# Altium schematic sheet AIM2_v0.9_PWR.SchDoc
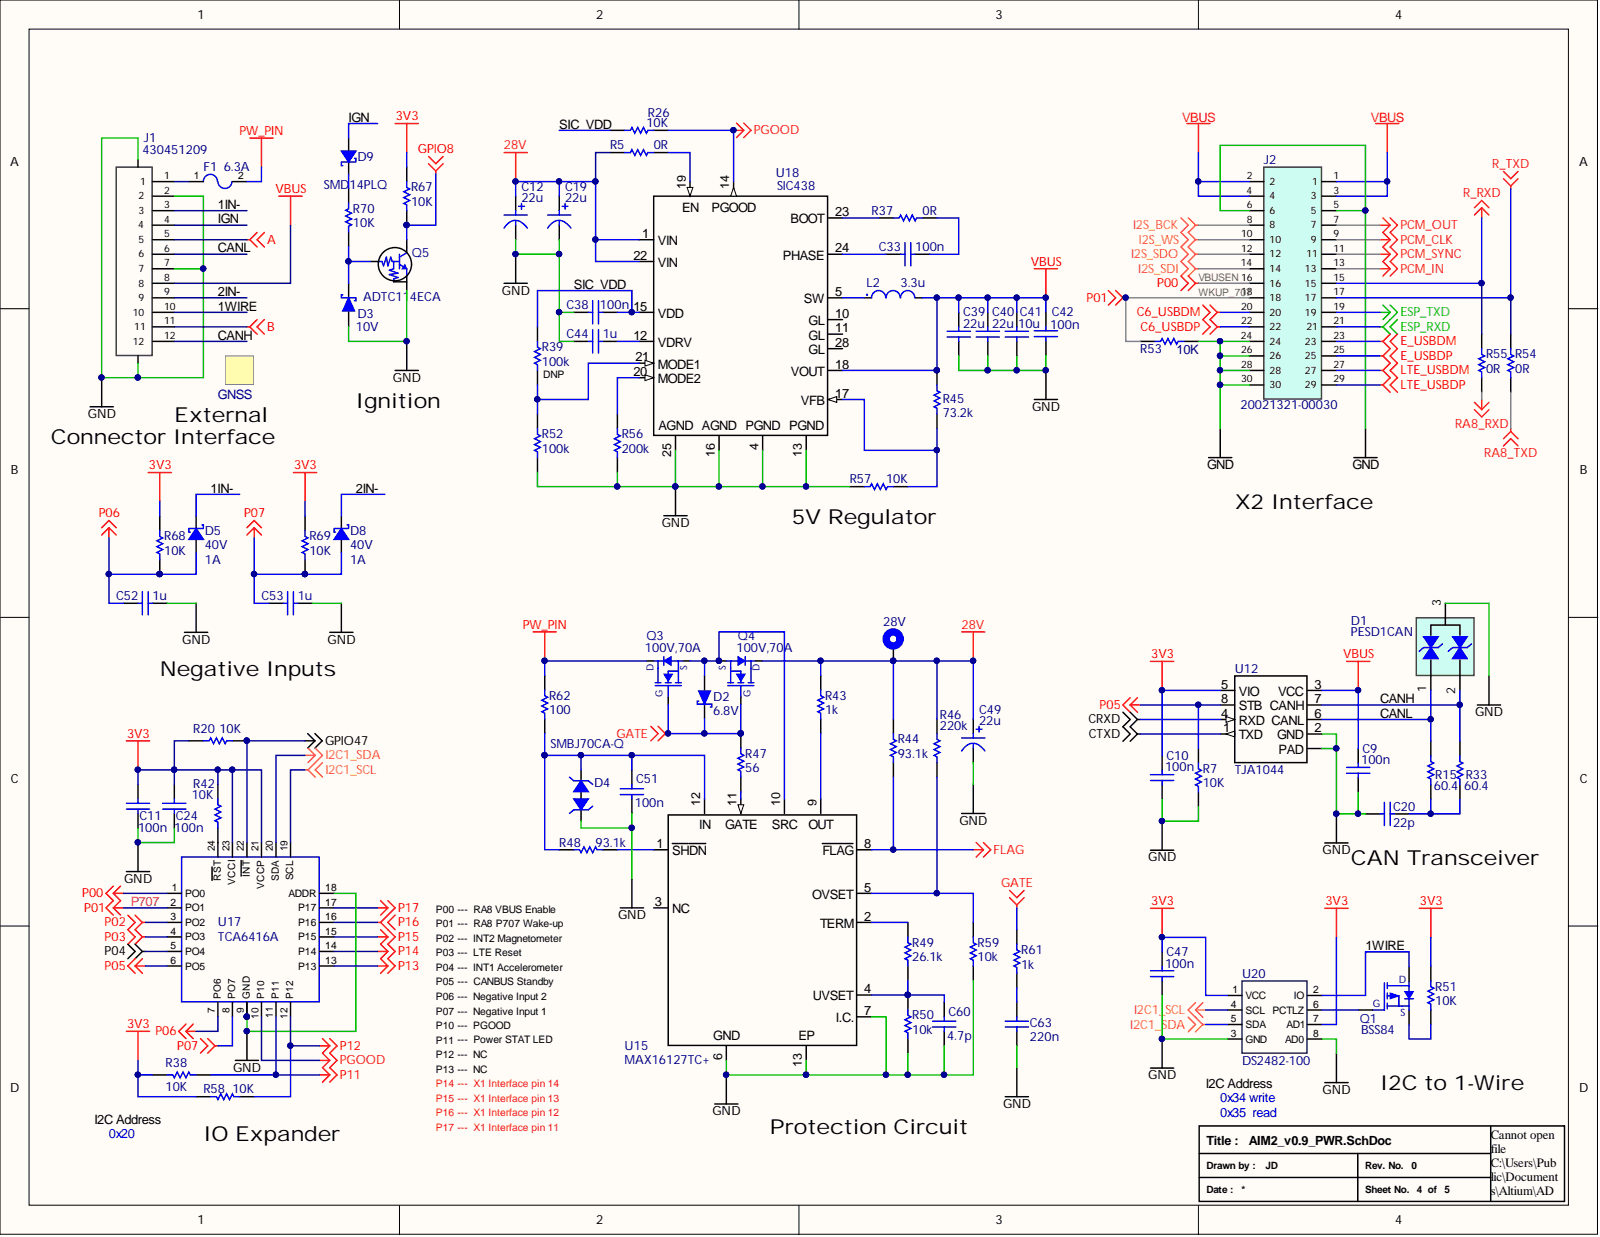
[diagram p0: AIM2_v0.9_PWR.SchDoc]

## Parts
28V  Testpoint_1_5  [Testpoint_1_5]  (value not drawn)
C9  100n  [CAP_0402_ghost]
C10  100n  [CAP_0402_ghost]
C11  100n  [CAP_0402]
C12  22u  [Alum_4x5.8]
C19  22u  [Alum_4x5.8]
C20  22p  [CAP_0402_ghost]
C24  100n  [CAP_0402]
C33  100n  [CAP_0402]
C38  100n  [CAP_0402]
C39  22u  [CAP_0603]
C40  22u  [CAP_0603]
C41  10u  [CAP_0603]
C42  100n  [CAP_0402]
C44  1u  [CAP_0402]
C47  100n  [CAP_0402]
C49  22u  [Alum_4x5.8]
C51  100n  [CAP_0603]
C52  1u  [CAP_0402]
C53  1u  [CAP_0402]
C60  4.7p  [CAP_0402]
C63  220n  [CAP_0603]
D1  PESD1CAN  [SOT 23_ghost]
D2  6.8V  [SOD323a]
D3  10V  [SOD323a]
D4  SMBJ70CA-Q  [DO-214AA]
D5  40V  Current: 1A  [SOD123]
D8  40V  Current: 1A  [SOD123]
D9  SMD14PLQ  [SOD123F-2]
F1  6.3A  [Fuse_3216]
GNSS  Antenna_18x18  [20x20]  (value not drawn)
J1  430451209  [Molex_Microfit_43045_1209]
J2  20021321-00030  [Minitek_20021321-00030T4LF]
L2  3.3u  [Ind_10.16x10.16]
Q1  BSS84  [SOT23-3]
Q3  100V,70A  [DPAK-3 (TO-252-3)]
Q4  100V,70A  [DPAK-3 (TO-252-3)]
Q5  ADTC114ECA  [SOT23-3]
R5  0R  [RES_0402]
R7  10K  [RES_0402_ghost]
R15  60.4  [RES_0402_ghost]
R20  10K  [RES_0402]
R26  10K  [RES_0402]
R33  60.4  [RES_0402_ghost]
R37  0R  [RES_0402]
R38  10K  [RES_0402]
R39  100k  [RES_0402_ghost]
R42  10K  [RES_0402]
R43  1k  [RES_0603]
R44  93.1k  [RES_0603]
R45  73.2k  [RES_0402]
R46  220k  [RES_0603]
R47  56  [RES_0603]
R48  93.1k  [RES_0603]
R49  26.1k  [RES_0603]
R50  10k  [RES_0603]
R51  10K  [RES_0402]
R52  100k  [RES_0402]
R53  10K  [RES_0402_ghost]
R54  0R  [RES_0402]
R55  0R  [RES_0402]
R56  200k  [RES_0402]
R57  10K  [RES_0402]
R58  10K  [RES_0402]
R59  10k  [RES_0603]
R61  1k  [RES_0603]
R62  100  [RES_1206]
R67  10K  [RES_0402]
R68  10K  [RES_0402]
R69  10K  [RES_0402]
R70  10K  [RES_0402]
U12  TJA1044  [S_PVSON_N8_DRB-A_ghost]
U15  MAX16127TC+  [TQFN-12L 3x3]
U17  TCA6416A  [S_PWQFN_N24_RTW]
U18  SIC438  [PowerPak_MLP44-24L]
U20  DS2482-100  [SOIC 150 (8-pin)]

## Nets
3V3: C10.2 C11.2 C24.2 C47.2 R20.1 R38.1 R42.1 R51.2 R58.1 R67.2 R68.2 R69.2 U12.5(VIO) U17.21(VCCP) U17.23(VCCI) U20.1(VCC) U20.7(AD1)
28V: 28V.1 C12.1 C19.1 C49.1 Q4.2 R5.1 R43.2 R44.2 R46.2 U18.1(VIN) U18.22(VIN)
A: J1.5
B: J1.11
C6_USBDM: J2.20
C6_USBDP: J2.22
CRXD: U12.4(RXD)
CTXD: U12.1(TXD)
E_USBDM: J2.23
E_USBDP: J2.25
ESP_RXD: J2.21
ESP_TXD: J2.19
FLAG: R44.1 U15.8(FLAG)
GATE: D2.K Q3.1 Q4.1 R47.2 R61.2
GND: C9.1 C10.1 C11.1 C12.2 C19.2 C20.1 C24.1 C38.1 C39.1 C40.1 C41.1 C42.1 C44.2 C47.1 C49.2 C51.1 C52.2 C53.2 C60.1 C63.1 D1.3 D3.A D4.A J1.0 J1.2 J1.7 J2.5 J2.6 J2.24 J2.26 J2.28 J2.30 Q5.2 R7.1 R50.1 R52.1 R53.1 R56.1 R57.2 R59.1 U12.0(PAD) U12.2(GND) U15.6 U15.7(I.C.) U15.13(EP) U17.9(GND) U17.18(ADDR) U17.25 U18.4 U18.13 U18.16 U18.25 U20.3(GND) U20.8(AD0)
GPIO8: Q5.3 R67.1
GPIO47: R20.2 U17.22(INT)
I2C1_SCL: U17.19(SCL) U20.4(SCL)
I2C1_SDA: U17.20(SDA) U20.5(SDA)
I2S_BCK: J2.8
I2S_SDI: J2.14
I2S_SDO: J2.12
I2S_WS: J2.10
LTE_USBDM: J2.27
LTE_USBDP: J2.29
P00: J2.16 U17.1(PO0)
P02: U17.3(PO2)
P03: U17.4(PO3)
P04: U17.5(PO4)
P05: R7.2 U12.8(STB) U17.6(PO5)
P06: C52.1 D5.A R68.1 U17.7(PO6)
P07: C53.1 D8.A R69.1 U17.8(PO7)
P11: R38.2 U17.11(P11)
P12: R58.2 U17.12(P12)
P13: U17.13(P13)
P14: U17.14(P14)
P15: U17.15(P15)
P16: U17.16(P16)
P17: U17.17(P17)
PCM_CLK: J2.9
PCM_IN: J2.13
PCM_OUT: J2.7
PCM_SYNC: J2.11
PGOOD: R26.1 U17.10(P10) U18.14
PW_PIN: F1.2 Q3.2 R62.2
R_RXD: J2.15 R55.1
R_TXD: J2.17 R54.1
RA8_RXD: R55.2
RA8_TXD: R54.2
VBUS: C9.2 C39.2 C40.2 C41.2 C42.2 J1.8 J2.1 J2.2 J2.3 J2.4 L2.2 R45.2 U12.3(VCC) U18.18(VOUT)
1IN-: D5.K J1.3
1WIRE: J1.10 Q1.3 U20.2(IO)
2IN-: D8.K J1.9
CANH: D1.2 J1.12 R33.2 U12.7(CANH)
CANL: D1.1 J1.6 R15.2 U12.6(CANL)
IGN: D9.A J1.4
P707: J2.18 R53.2 U17.2(PO1)
SIC_VDD: C38.2 R26.2 R39.2 U18.15(VDD)
NetC20_2: C20.2 R15.1 R33.1
NetC33_1: C33.1 R37.2
NetC33_2: C33.2 U18.24(PHASE)
NetC44_1: C44.1 U18.12(VDRV)
NetC51_2: C51.2 D4.K R48.1 R62.1 U15.12(IN)
NetC60_2: C60.2 R49.1 R50.2 U15.4(UVSET)
NetC63_2: C63.2 R61.1
NetD2_A: D2.A Q3.3 Q4.3 U15.10
NetD3_K: D3.K Q5.1 R70.1
NetD9_K: D9.K R70.2
NetF1_1: F1.1 J1.1
NetL2_1: L2.1 U18.5(SW)
NetQ1_1: Q1.1 U20.6(PCTLZ)
NetQ1_2: Q1.2 R51.1
NetR5_2: R5.2 U18.19(EN)
NetR37_1: R37.1 U18.23(BOOT)
NetR39_1: R39.1 R52.2 U18.21(MODE1)
NetR42_2: R42.2 U17.24(RST)
NetR43_1: R43.1 U15.9
NetR45_1: R45.1 R57.1 U18.17(VFB)
NetR46_1: R46.1 R59.2 U15.5(OVSET)
NetR47_1: R47.1 U15.11
NetR48_2: R48.2 U15.1(SHDN)
NetR49_2: R49.2 U15.2(TERM)
NetR56_2: R56.2 U18.20(MODE2)

Not connected: U15 3(NC); U18 10(GL) 11(GL) 28(GL)

## Library data of the parts
C9 C10 C47 = Cap_100nF,10V,10%,X5R,0402: Component Kind: Standard; Library Name: Cap.SchLib; Supplier 1: Digi-Key; Supplier 2: Mouser; Supplier Part Number 1: 490-1318-2-ND; Supplier Part Number 2: 77-VJ0402G104KXQCBC; Value: 100n
C11 C24 = Cap_0.1uF,10V,10%,X5R,0402: Component Kind: Standard; Library Name: Cap.SchLib; Supplier 1: Mouser; Supplier Part Number 1: 81-GRM155R61A104KA01; Value: 100n
C12 C19 C49 = Alum_22uF,35V,20%,4x5.8: Component Kind: Standard; Library Name: Cap.SchLib; Supplier 1: Rs; Supplier Part Number 1: 2437248P; Value: 22u
C20 = Cap_22pF,50V,NP0,5%,0402: Component Kind: Standard; Library Name: Cap.SchLib; Supplier 1: Mouser; Supplier Part Number 1: 81-GRM1555C1H220JA1D; Value: 22p
C33 C38 C42 = Cap_100nF,50V,X5R,10%,0402: Component Kind: Standard; Library Name: Cap.SchLib; Supplier 1: Mouser; Supplier Part Number 1: 81-GRM155R61H104KE4D; Value: 100n
C39 C40 = Cap_22uF,10V,X5R,20%,0603: Component Kind: Standard; Library Name: Cap.SchLib; Supplier 1: Mouser; Supplier Part Number 1: 81-GRM188R61A226ME5D; Value: 22u
C41 = Cap_10uF,16V,X5R,10%,0603: Component Kind: Standard; Library Name: Cap.SchLib; Supplier 1: Mouser; Supplier Part Number 1: 81-GRT188R61C106KE3D; Value: 10u
C44 = Cap_1uF,25V,X5R, 10%,0402: Component Kind: Standard; Library Name: Cap.SchLib; Supplier 1: Mouser; Supplier Part Number 1: 81-GRM155R61E105KA2D; Value: 1u
C51 = Cap_100nF,100V,10%,X7R,0603: Component Kind: Standard; Library Name: Cap.SchLib; Supplier 1: Mouser; Supplier Part Number 1: HMJ107BB7104KAHT; Value: 100n
C52 C53 = Cap_1uF,10V,20%,X5R,0402: Component Kind: Standard; Library Name: Cap.SchLib; Supplier 1: Mouser; Supplier Part Number 1: 81-GRM155R61A105ME1D; Value: 1u
C60 = Cap_4.7pF,50V,0.25pF,C0G,0402: Component Kind: Standard; Library Name: Cap.SchLib; Supplier 1: Mouser; Supplier Part Number 1: GJM1555C1H4R7CB01J; Value: 4.7p
C63 = Cap_220nF,100V,X5R,20%,0603: Component Kind: Standard; Library Name: Cap.SchLib; Supplier 1: Mouser; Supplier Part Number 1: MSASH168SB5224MTCA01; Value: 220n
D1 = ESD_PESD1CAN: Component Kind: Standard; Library Name: Diode.SchLib; Supplier 1: Mouser; Supplier Part Number 1: 771-PESD1CAN-T/R; Value: PESD1CAN
D2 = Zen_6.8V,200mW,5%,2uA,15mOhm,SOD323-2: Component Kind: Standard; Library Name: Diode.SchLib; Supplier 1: Mouser; Supplier Part Number 1: 78-BZX384C6V8-E3-18; Value: 6.8V
D3 = Zen_10V,5mAIzt,0.2AIr,SOD323: Component Kind: Standard; Library Name: Diode.SchLib; Supplier 1: Mouser; Supplier Part Number 1: 78-BZX384B10-E3-18; Value: 10V
D4 = TVS_70Vrwm,77.8Vbrk,113Vc,5.3A,DO214AA-2: Component Kind: Standard; Library Name: Diode.SchLib; Supplier 1: Mouser; Supplier Part Number 1: 652-SMBJ70CA-QH; Value: SMBJ70CA-Q
D5 D8 = Schot_ 40V, 1A: Component Kind: Standard; Library Name: Diode.SchLib; Supplier 1: Mouser; Supplier Part Number 1: 621-1N5819HW-F; Value: 40V
D9 = Schot_SMD14PLQ-TP: Component Kind: Standard; Library Name: Diode.SchLib; Supplier 1: Mouser; Supplier Part Number 1: 833-SMD14PLQ-TP; Value: SMD14PLQ
F1 = Fuse_6.3A,63Vdc,3216: Component Kind: Standard; Library Name: Diode.SchLib; Supplier 1: Mouser; Supplier Part Number 1: 693-3413.0224.11; Value: 6.3A
J1 = Molex_Microfit_43045_1209: Component Kind: Standard; Library Name: SW.SchLib; Supplier 1: Mouser; Supplier Part Number 1: 538-43045-1209; Value: 430451209
J2 = Minitek_20021321-00030: Component Kind: Standard; Library Name: SW.SchLib; Supplier 1: Digi-Key; Supplier Part Number 1: 609-3776-ND; Value: 20021321-00030
L2 = Ind_3.3uH,11A,11.81mohm,10.16x10.16: Component Kind: Standard; Library Name: Inductor.SchLib; Supplier 1: Mouser; Supplier Part Number 1: 70-IHLP4040DZR3R3M5A; Value: 3.3u
Q1 = MOSFET_-50V,-130mA,10Ohm,P-chan: Component Kind: Standard; Library Name: Trans.SchLib; Supplier 1: Mouser; Supplier Part Number 1: 621-BSS84-F; Value: BSS84
Q3 Q4 = MOSFET_100V,70A,10mOhm,N-channel,DPAK-3: Component Kind: Standard; Library Name: Trans.SchLib; Supplier 1: Mouser; Supplier Part Number 1: 511-STD85N10F7AG; Value: 100V,70A
Q5 = BJT_NPN,VCEO50V,VBEO40V,SOT23: Component Kind: Standard; Library Name: Trans.SchLib; Supplier 1: Mouser; Supplier Part Number 1: 621-ADTC114ECAQ-7; Value: ADTC114ECA
R5 R37 R54 R55 = Res_0R,1%,1/16W, 0402: Component Kind: Standard; Library Name: RES.SchLib; Supplier 1: Mouser; Supplier Part Number 1: 603-RC0402JR-070RL; Value: 0R
R7 R20 R26 R38 R42 R51 R53 R57 R58 R67-R70 = Res_10K, 1%,1/16W,0402: Component Kind: Standard; Library Name: RES.SchLib; Supplier 1: Mouser; Supplier Part Number 1: 603-RC0402FR-0710KL; Value: 10K
R15 R33 = Res_60.4R,1%,1/16W,0402: Component Kind: Standard; Library Name: RES.SchLib; Supplier 1: Mouser; Supplier Part Number 1: 603-RC0402FR-0760R4L; Value: 60.4
R39 R52 = Res_100k,5%,1/16W, 0402: Component Kind: Standard; Library Name: RES.SchLib; Supplier 1: Mouser; Supplier Part Number 1: 603-RC0402JR-07100KL; Value: 100k
R43 R61 = Res_1k,1%,100mW,0603: Component Kind: Standard; Library Name: RES.SchLib; Supplier 1: Mouser; Supplier Part Number 1: 603-RC0603FR-0756RL; Value: 1k
R44 R48 = Res_93.1k,1%,100mW,0603: Component Kind: Standard; Library Name: RES.SchLib; Supplier 1: Mouser; Supplier Part Number 1: RC0603FR-0793K1L; Value: 93.1k
R45 = Res_73.2k,1%,1/16W,0402: Component Kind: Standard; Library Name: RES.SchLib; Supplier 1: Mouser; Supplier Part Number 1: 603-RC0402FR-0773K2L; Value: 73.2k
R46 = Res_220k,1%,100mW,0603: Component Kind: Standard; Library Name: RES.SchLib; Supplier 1: Mouser; Supplier Part Number 1: RC0603FR-10220KL; Value: 220k
R47 = Res_56R,1%,100mW,0603: Component Kind: Standard; Library Name: RES.SchLib; Supplier 1: Mouser; Supplier Part Number 1: 603-RC0603FR-1356RL; Value: 56
R49 = Res_26.1k,1%,1/16W,0603: Component Kind: Standard; Library Name: RES.SchLib; Supplier 1: Mouser; Supplier Part Number 1: 603-RC0603FR-0726K1L; Value: 26.1k
R50 R59 = Res_10k,1%,250mW,0603: Component Kind: Standard; Library Name: RES.SchLib; Supplier 1: Mouser; Supplier Part Number 1: 279-CRGP0603F10K; Value: 10k
R56 = Res_200k,1%,1/16W,0402: Component Kind: Standard; Library Name: RES.SchLib; Supplier 1: Mouser; Supplier Part Number 1: 603-RE0402FRE07200KL; Value: 200k
R62 = Res_100R,1%,750mW,1206: Component Kind: Standard; Library Name: RES.SchLib; Supplier 1: Mouser; Supplier Part Number 1: 71-CRCW1206100RFKEAH; Value: 100
U12 = NXP_TJA1044: Component Kind: Standard; Library Name: ICs.SchLib; Supplier 1: Mouser; Supplier Part Number 1: 771-TJA1044GTK/3Z; Value: TJA1044
U17 = TCA6416ARTWR: Component Kind: Standard; Library Name: ICs.SchLib; Supplier 1: Mouser; Supplier Part Number 1: 595-TCA6416ARTWR; Value: TCA6416A
U18 = Vishay_SIC438BED-T1-GE3: Component Kind: Standard; Library Name: ICs.SchLib; Value: SIC438
28V = Testpoint_1_5: Component Kind: Standard; Library Name: SW.SchLib
GNSS = Antenna_18x18: Component Kind: Standard; Library Name: Mix_Interim.SchLib
U15 = MAX16127TC+: Component Kind: Standard; Library Name: ICs.SchLib; Supplier 1: Mouser; Supplier Part Number 1: 700-MAX16127TC/V+T; Value: MAX16127TC+
U20 = DS2482-100: Component Kind: Standard; Library Name: ICs.SchLib; Supplier 1: Digi-Key; Supplier Part Number 1: DS2482S-100+T&RCT-ND; Value: DS2482-100
